# Altium schematic sheet 3-RotaryDials.SchDoc(3-RotaryDials)
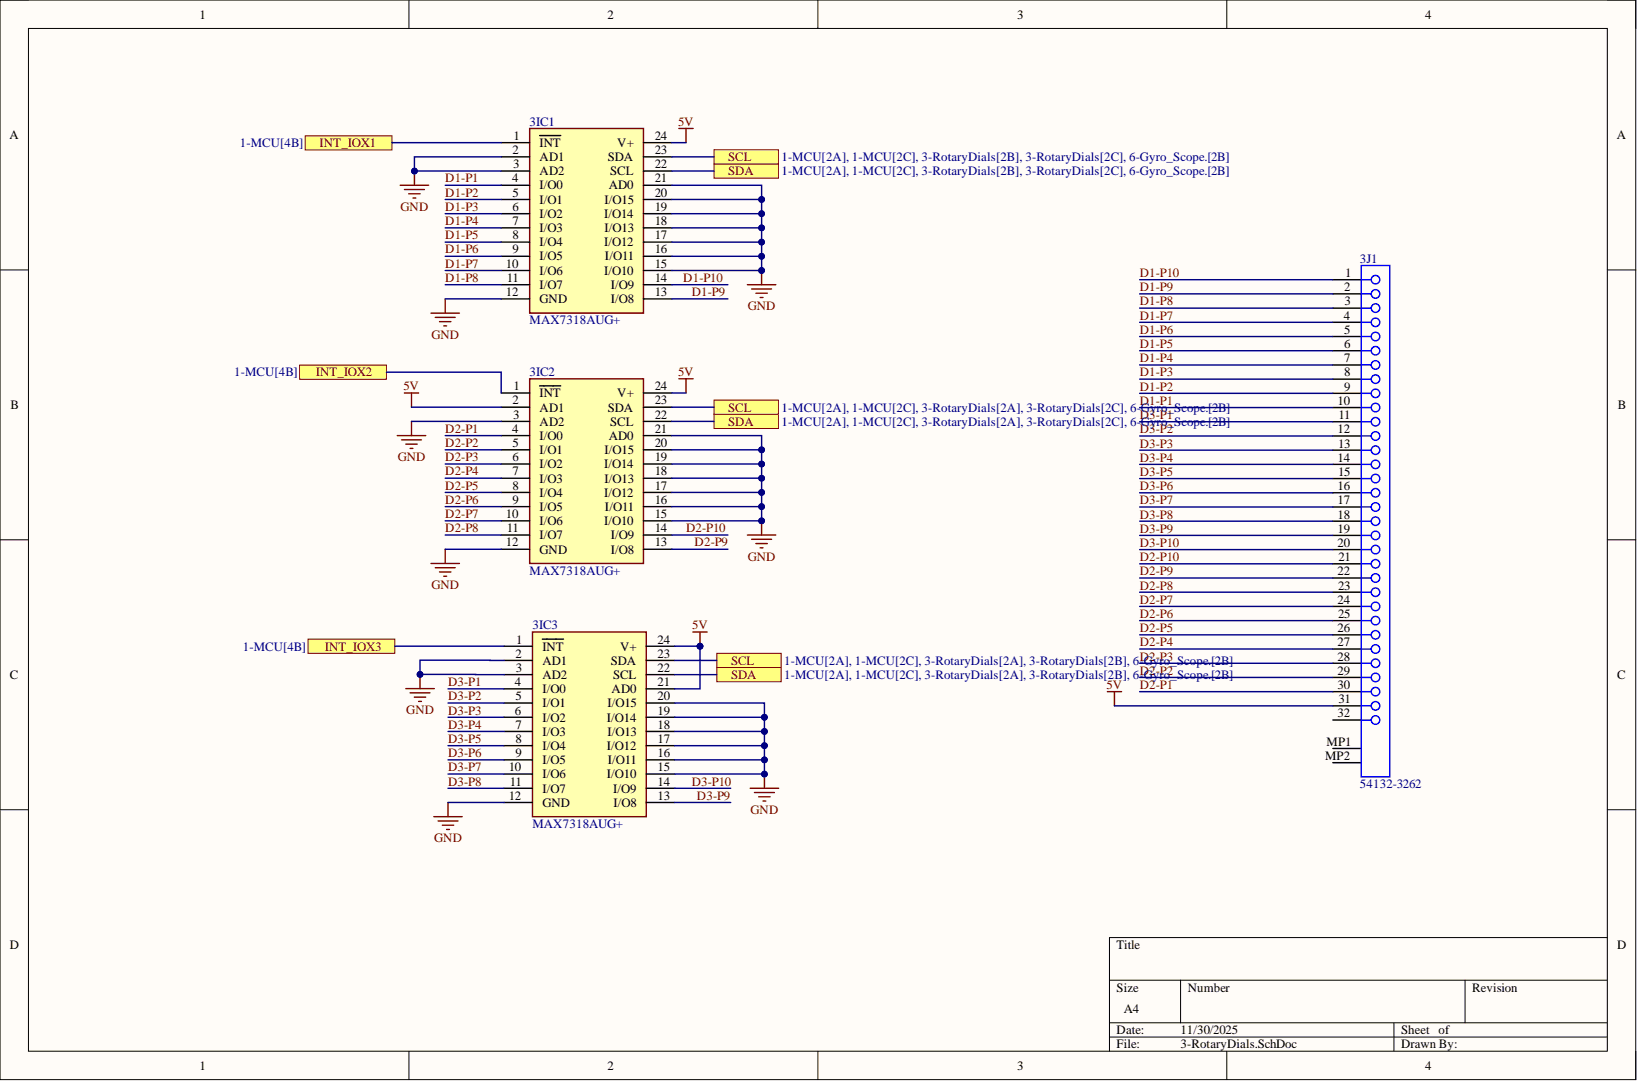
[diagram p0: 3-RotaryDials.SchDoc]

## Parts
3IC1  MAX7318AUG+
3IC2  MAX7318AUG+
3IC3  MAX7318AUG+
3J1  54132-3262

## Ports
INT_IOX1, INT_IOX2, INT_IOX3, SCL, SDA

## Nets
5V: 3IC1.24(V+) 3IC2.2(AD1) 3IC2.24(V+) 3IC3.21(AD0) 3IC3.24(V+) 3J1.31
GND: 3IC1.2(AD1) 3IC1.3(AD2) 3IC1.12(GND) 3IC1.15(I/O10) 3IC1.16(I/O11) 3IC1.17(I/O12) 3IC1.18(I/O13) 3IC1.19(I/O14) 3IC1.20(I/O15) 3IC1.21(AD0) 3IC2.3(AD2) 3IC2.12(GND) 3IC2.15(I/O10) 3IC2.16(I/O11) 3IC2.17(I/O12) 3IC2.18(I/O13) 3IC2.19(I/O14) 3IC2.20(I/O15) 3IC2.21(AD0) 3IC3.2(AD1) 3IC3.3(AD2) 3IC3.12(GND) 3IC3.15(I/O10) 3IC3.16(I/O11) 3IC3.17(I/O12) 3IC3.18(I/O13) 3IC3.19(I/O14) 3IC3.20(I/O15)
D1-P1: 3IC1.4(I/O0) 3J1.10
D1-P2: 3IC1.5(I/O1) 3J1.9
D1-P3: 3IC1.6(I/O2) 3J1.8
D1-P4: 3IC1.7(I/O3) 3J1.7
D1-P5: 3IC1.8(I/O4) 3J1.6
D1-P6: 3IC1.9(I/O5) 3J1.5
D1-P7: 3IC1.10(I/O6) 3J1.4
D1-P8: 3IC1.11(I/O7) 3J1.3
D1-P9: 3IC1.13(I/O8) 3J1.2
D1-P10: 3IC1.14(I/O9) 3J1.1
D2-P1: 3IC2.4(I/O0) 3J1.30
D2-P2: 3IC2.5(I/O1) 3J1.29
D2-P3: 3IC2.6(I/O2) 3J1.28
D2-P4: 3IC2.7(I/O3) 3J1.27
D2-P5: 3IC2.8(I/O4) 3J1.26
D2-P6: 3IC2.9(I/O5) 3J1.25
D2-P7: 3IC2.10(I/O6) 3J1.24
D2-P8: 3IC2.11(I/O7) 3J1.23
D2-P9: 3IC2.13(I/O8) 3J1.22
D2-P10: 3IC2.14(I/O9) 3J1.21
D3-P1: 3IC3.4(I/O0) 3J1.11
D3-P2: 3IC3.5(I/O1) 3J1.12
D3-P3: 3IC3.6(I/O2) 3J1.13
D3-P4: 3IC3.7(I/O3) 3J1.14
D3-P5: 3IC3.8(I/O4) 3J1.15
D3-P6: 3IC3.9(I/O5) 3J1.16
D3-P7: 3IC3.10(I/O6) 3J1.17
D3-P8: 3IC3.11(I/O7) 3J1.18
D3-P9: 3IC3.13(I/O8) 3J1.19
D3-P10: 3IC3.14(I/O9) 3J1.20
INT_IOX1: 3IC1.1(INT) ; port INT_IOX1
INT_IOX2: 3IC2.1(INT) ; port INT_IOX2
INT_IOX3: 3IC3.1(INT) ; port INT_IOX3
SCL: 3IC1.23(SDA) 3IC2.23(SDA) 3IC3.23(SDA) ; port SCL
SDA: 3IC1.22(SCL) 3IC2.22(SCL) 3IC3.22(SCL) ; port SDA

Not connected: 3J1 32 MP1 MP2

## Library data of the parts
3IC1-3IC3 = MAX7318AUG+: Integrated Circuit; Manufacturer_Name: Analog Devices; Component Kind: Standard; Datasheet Link: https://datasheets.maximintegrated.com/en/ds/MAX7318.pdf; Height: 1.1mm; Library Name: SamacSys.SchLib; Manufacturer_Part_Number: MAX7318AUG+; Mouser Part Number: 700-MAX7318AUG; Mouser Price/Stock: https://www.mouser.co.uk/ProductDetail/Maxim-Integrated/MAX7318AUG%2b?qs=LHmEVA8xxfYgssgPLllRdg%3D%3D
3J1 = CMP-1241-00519-1: 0.5 mm Pitch Easy-On(TM) Type FPC Connector, 2.0 mm Mated Height, Right Angle, ZIF, SMT, Bottom Contact, 32 Circuits, -20 to 85 degC, ELV and RoHS Compliant, Tape and Reel; Manufacturer: Molex; Circuits (Loaded): 32; Current (Max per Contact): 0.5A; Durability (mating cycles max): 20; Entry Angle: 90degrees Angle; Mated Height: 2.00mm; Material - Metal: Phosphor Bronze; Material - Plating Mating: Gold; PCB Locator: No; PCB Retention: Yes; Pitch - Mating Interface: 0.50mm; Voltage (Max): 50V; Component Kind: Standard; ComponentLink1Description: Manufacturer URL; ComponentLink1URL: http://www.molex.com/molex/index.jsp; ComponentLink2Description: Datasheet; ComponentLink2URL: http://www.molex.com/webdocs/datasheets/pdf/en-us/0541323262_FFC_FPC_CONNECTORS.pdf; ComponentLink3Description: Package Specification; ComponentLink3URL: http://www.molex.com/pdm_docs/sd/541323262_sd.pdf; Contact Position: Bottom; Library Name: Altium Content Vault; Material - Plating Termination: Gold; Mounting Technology: Surface Mount; Number of Rows: 1; Orientation: Right Angle; PackageDescription: 32-Lead FPC Connector, Pitch 0.5 mm; PackageReference: 54132-3262; PackageVersion: Rev. F, 07/2010; Packing: Tape and Reel; PartNumber: 54132-3262; Polarized to PCB: Yes; RoHS: TRUE; Stackable: No
